# Altium schematic sheet WS2818B.SchDoc
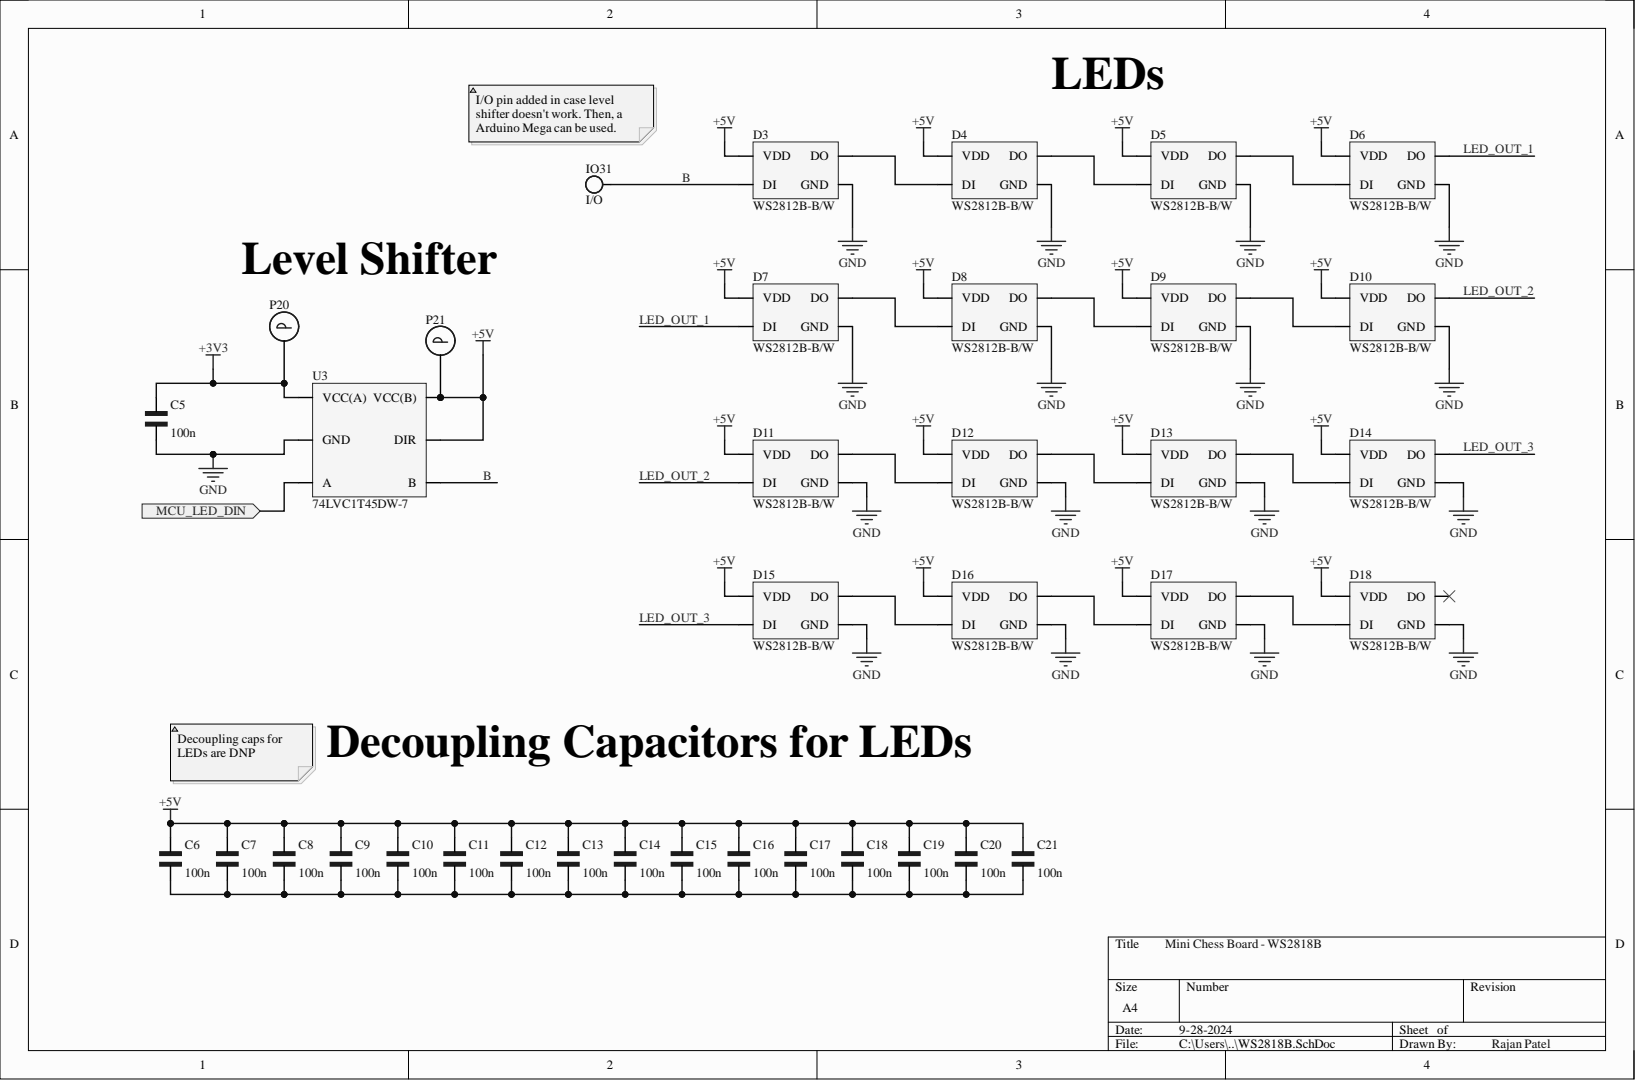
[diagram p0: WS2818B.SchDoc]

## Parts
C5  100n  [CAP 0805]
C6  100n  [CAP 0805]
C7  100n  [CAP 0805]
C8  100n  [CAP 0805]
C9  100n  [CAP 0805]
C10  100n  [CAP 0805]
C11  100n  [CAP 0805]
C12  100n  [CAP 0805]
C13  100n  [CAP 0805]
C14  100n  [CAP 0805]
C15  100n  [CAP 0805]
C16  100n  [CAP 0805]
C17  100n  [CAP 0805]
C18  100n  [CAP 0805]
C19  100n  [CAP 0805]
C20  100n  [CAP 0805]
C21  100n  [CAP 0805]
D3  WS2812B-B/W  [WS2812B-B/W]
D4  WS2812B-B/W  [WS2812B-B/W]
D5  WS2812B-B/W  [WS2812B-B/W]
D6  WS2812B-B/W  [WS2812B-B/W]
D7  WS2812B-B/W  [WS2812B-B/W]
D8  WS2812B-B/W  [WS2812B-B/W]
D9  WS2812B-B/W  [WS2812B-B/W]
D10  WS2812B-B/W  [WS2812B-B/W]
D11  WS2812B-B/W  [WS2812B-B/W]
D12  WS2812B-B/W  [WS2812B-B/W]
D13  WS2812B-B/W  [WS2812B-B/W]
D14  WS2812B-B/W  [WS2812B-B/W]
D15  WS2812B-B/W  [WS2812B-B/W]
D16  WS2812B-B/W  [WS2812B-B/W]
D17  WS2812B-B/W  [WS2812B-B/W]
D18  WS2812B-B/W  [WS2812B-B/W]
IO31  I/O  [I/O]
P20  Probe_5019  [Testpoint]  (value not drawn)
P21  Probe_5019  [Testpoint]  (value not drawn)
U3  74LVC1T45DW-7  [74LVC1T45DW-7]

## Ports
MCU_LED_DIN

## Nets
+3V3: C5.2 P20.1 U3.1(VCC(A))
+5V: C6.2 C7.2 C8.2 C9.2 C10.2 C11.2 C12.2 C13.2 C14.2 C15.2 C16.2 C17.2 C18.2 C19.2 C20.2 C21.2 D3.1(VDD) D4.1(VDD) D5.1(VDD) D6.1(VDD) D7.1(VDD) D8.1(VDD) D9.1(VDD) D10.1(VDD) D11.1(VDD) D12.1(VDD) D13.1(VDD) D14.1(VDD) D15.1(VDD) D16.1(VDD) D17.1(VDD) D18.1(VDD) P21.1 U3.5(DIR) U3.6(VCC(B))
GND: C5.1 D3.4(GND) D4.4(GND) D5.4(GND) D6.4(GND) D7.4(GND) D8.4(GND) D9.4(GND) D10.4(GND) D11.4(GND) D12.4(GND) D13.4(GND) D14.4(GND) D15.4(GND) D16.4(GND) D17.4(GND) D18.4(GND) U3.2(GND)
B: D3.2(DI) IO31.1 U3.4(B)
LED_OUT_1: D6.3(DO) D7.2(DI)
LED_OUT_2: D10.3(DO) D11.2(DI)
LED_OUT_3: D14.3(DO) D15.2(DI)
MCU_LED_DIN: U3.3(A) ; port MCU_LED_DIN
NetC6_1: C6.1 C7.1 C8.1 C9.1 C10.1 C11.1 C12.1 C13.1 C14.1 C15.1 C16.1 C17.1 C18.1 C19.1 C20.1 C21.1
NetD3_3: D3.3(DO) D4.2(DI)
NetD4_3: D4.3(DO) D5.2(DI)
NetD5_3: D5.3(DO) D6.2(DI)
NetD7_3: D7.3(DO) D8.2(DI)
NetD8_3: D8.3(DO) D9.2(DI)
NetD9_3: D9.3(DO) D10.2(DI)
NetD11_3: D11.3(DO) D12.2(DI)
NetD12_3: D12.3(DO) D13.2(DI)
NetD13_3: D13.3(DO) D14.2(DI)
NetD15_3: D15.3(DO) D16.2(DI)
NetD16_3: D16.3(DO) D17.2(DI)
NetD17_3: D17.3(DO) D18.2(DI)

Not connected: D18 3(DO)

## Library data of the parts
C5-C21 = CAP 0805: Check_prices: https://www.snapeda.com/parts/C2012X8R1H104K125AA/TDK/view-part/?ref=eda; Component Kind: Standard; SnapEDA_Link: https://www.snapeda.com/parts/C2012X8R1H104K125AA/TDK/view-part/?ref=snap; Library Name: ChessBoardSchLib.SchLib
D3-D18 = WS2812B-B/W: SMD5050 RGB LEDs(Built-in IC) ROHS; Manufacturer: Worldsemi; Component Kind: Standard; Manufacturer Part Number: WS2812B-B/W; Supplier: LCSC; Supplier Part Number: C114586; Library Name: ChessBoardSchLib.SchLib
P20 P21 = Probe_5019: Phosphor Bronze Contact Miniature Silver Plated Surface Mount Test Points; Manufacturer: Keystone Electronics; Manufacturer Part Number: 5019; Body Material: Bronze; Category: Connectors; Component Kind: Standard; ComponentLink1Description: https://datasheet.ciiva.com/16318/m60-2p61-16318395.pdf?src-supplier=Future+Electronics; ComponentLink1URL: https://datasheet.ciiva.com/16318/m60-2p61-16318395.pdf?src-supplier=Future+Electronics; ComponentLink2Description: https://datasheet.ciiva.com/16885/70182998-16885945.pdf?src-supplier=Allied+Electronics; ComponentLink2URL: https://datasheet.ciiva.com/16885/70182998-16885945.pdf?src-supplier=Allied+Electronics; ComponentLink3Description: https://datasheet.ciiva.com/14848/m60-2p61-14848437.pdf?src-supplier=Digi-Key; ComponentLink3URL: https://datasheet.ciiva.com/14848/m60-2p61-14848437.pdf?src-supplier=Digi-Key; ComponentLink4Description: https://datasheet.ciiva.com/14848/m60-2p61-14848438.pdf?src-supplier=Digi-Key; ComponentLink4URL: https://datasheet.ciiva.com/14848/m60-2p61-14848438.pdf?src-supplier=Digi-Key; ComponentLink5Description: https://datasheet.ciiva.com/14848/m60-2p61-14848439.pdf?src-supplier=Digi-Key; ComponentLink5URL: https://datasheet.ciiva.com/14848/m60-2p61-14848439.pdf?src-supplier=Digi-Key; Contact Material: Bronze; Contact Plating: Silver; Height: 0.09inch; Lead Free: Lead Free; Material: Bronze; Mount: Surface Mount,PCB; Number of Pins: 1; Package Quantity: 1; Packaging: Tape and Reel; Plating: Silver; Pricing 1: 1=0.12300, 1000=0.12300, 2000=0.11700, 4000=0.11300, 6000=0.11000 (USD); Reach SVHC Compliant: Yes; RoHS: Yes; RoHS Compliant: Yes; Series: 5019; Stock 1: 148000; Supplier 1: Newark; Supplier Part Number 1: 06W4636; Termination: SMD/SMT; Library Name: MiniChessBoardSchLib.SchLib
U3 = 74LVC1T45DW-7: Bus Transceiver, LVC/LCX/Z Series, 1-Func, 1-Bit, True Output, CMOS, PDSO6; Manufacturer: Diodes; Channels: 1; Control Type: COMMON CONTROL; Count Direction: Bidirectional; Load/Preset Input: Yes; Max I(ol): 24mA; Max Supply Voltage: 5.5V; Min Supply Voltage: 1.65V; Nominal Supply Voltage: 3.3V; Number of Ports: 2; Output Characteristics: 3-STATE; Package Body Material: Plastic; Package Equivalence Code: TSSOP6,.08; Qualification Status: Not Qualified; Case/Package: TSSOP; Component Kind: Standard; ComponentLink1Description: https://datasheet.ciiva.com:443/pdfs/VipMasterIC/IC/DIOD/DIOD-S-A0006644025/DIOD-S-A0006644025-1.pdf?src-supplier=IHS+Markit; ComponentLink1URL: https://datasheet.ciiva.com:443/pdfs/VipMasterIC/IC/DIOD/DIOD-S-A0006644025/DIOD-S-A0006644025-1.pdf?src-supplier=IHS+Markit; ComponentLink2Description: http://www.mouser.com/ds/2/115/74LVC1T45-318124.pdf?src-supplier=Mouser; ComponentLink2URL: http://www.mouser.com/ds/2/115/74LVC1T45-318124.pdf?src-supplier=Mouser; ComponentLink3Description: https://www.diodes.com/assets/Datasheets/74LVC1T45.pdf?src-supplier=Digikey; ComponentLink3URL: https://www.diodes.com/assets/Datasheets/74LVC1T45.pdf?src-supplier=Digikey; ComponentLink4Description: https://datasheet.octopart.com/74LVC1T45DW-7-Diodes-Inc.-datasheet-160640065.pdf?src-supplier=Newark; ComponentLink4URL: https://datasheet.octopart.com/74LVC1T45DW-7-Diodes-Inc.-datasheet-160640065.pdf?src-supplier=Newark; ComponentLink5Description: http://www.diodes.com/datasheets/74LVC1T45.pdf?src-supplier=Avnet; ComponentLink5URL: http://www.diodes.com/datasheets/74LVC1T45.pdf?src-supplier=Avnet; Height - Seated (Max): 1.1mm; JESD-30 Code: R-PDSO-G6; JESD-609 Code: e3; Length: 2mm; Logic Type: BUS TRANSCEIVER; Manufacturer Part Number: 74LVC1T45DW-7; Max Operating Temperature: 125°C; Min Operating Temperature: -40°C; Moisture Sensitivity Level: 1; Number of Bits: 1; Output Polarity: True; Package Shape: Rectangular; Package Style: SMALL OUTLINE, THIN PROFILE, SHRINK PITCHMeter; Packaging: Tape and Reel; Pins: 6; Power Supplies: 3.3V; Pricing: 3000=0.0512, 9000=0.0494, 15000=0.0485, 60000=0.0463, 90000=0.0449 (USD); Reach SVHC Compliant: Yes; RoHS: Yes; RoHS Compliant: Yes; Stock: 42000; Supplier: Future Electronics; Supplier Part Number: 3070442; Surface Mount: Yes; Technology: CMOS; Temperature Grade: AUTOMOTIVE; Terminal Finish: Tin; Terminal Form: Gull Wing; Terminal Pitch: 0.65mm; Terminal Position: DUAL; Width: 1.25mm; Library Name: ChessBoardSchLib.SchLib
IO31 = I/O: Component Kind: Standard; Library Name: MiniChessBoardSchLib.SchLib
